# Altium schematic sheet 02_USB2.0_Module.SchDoc
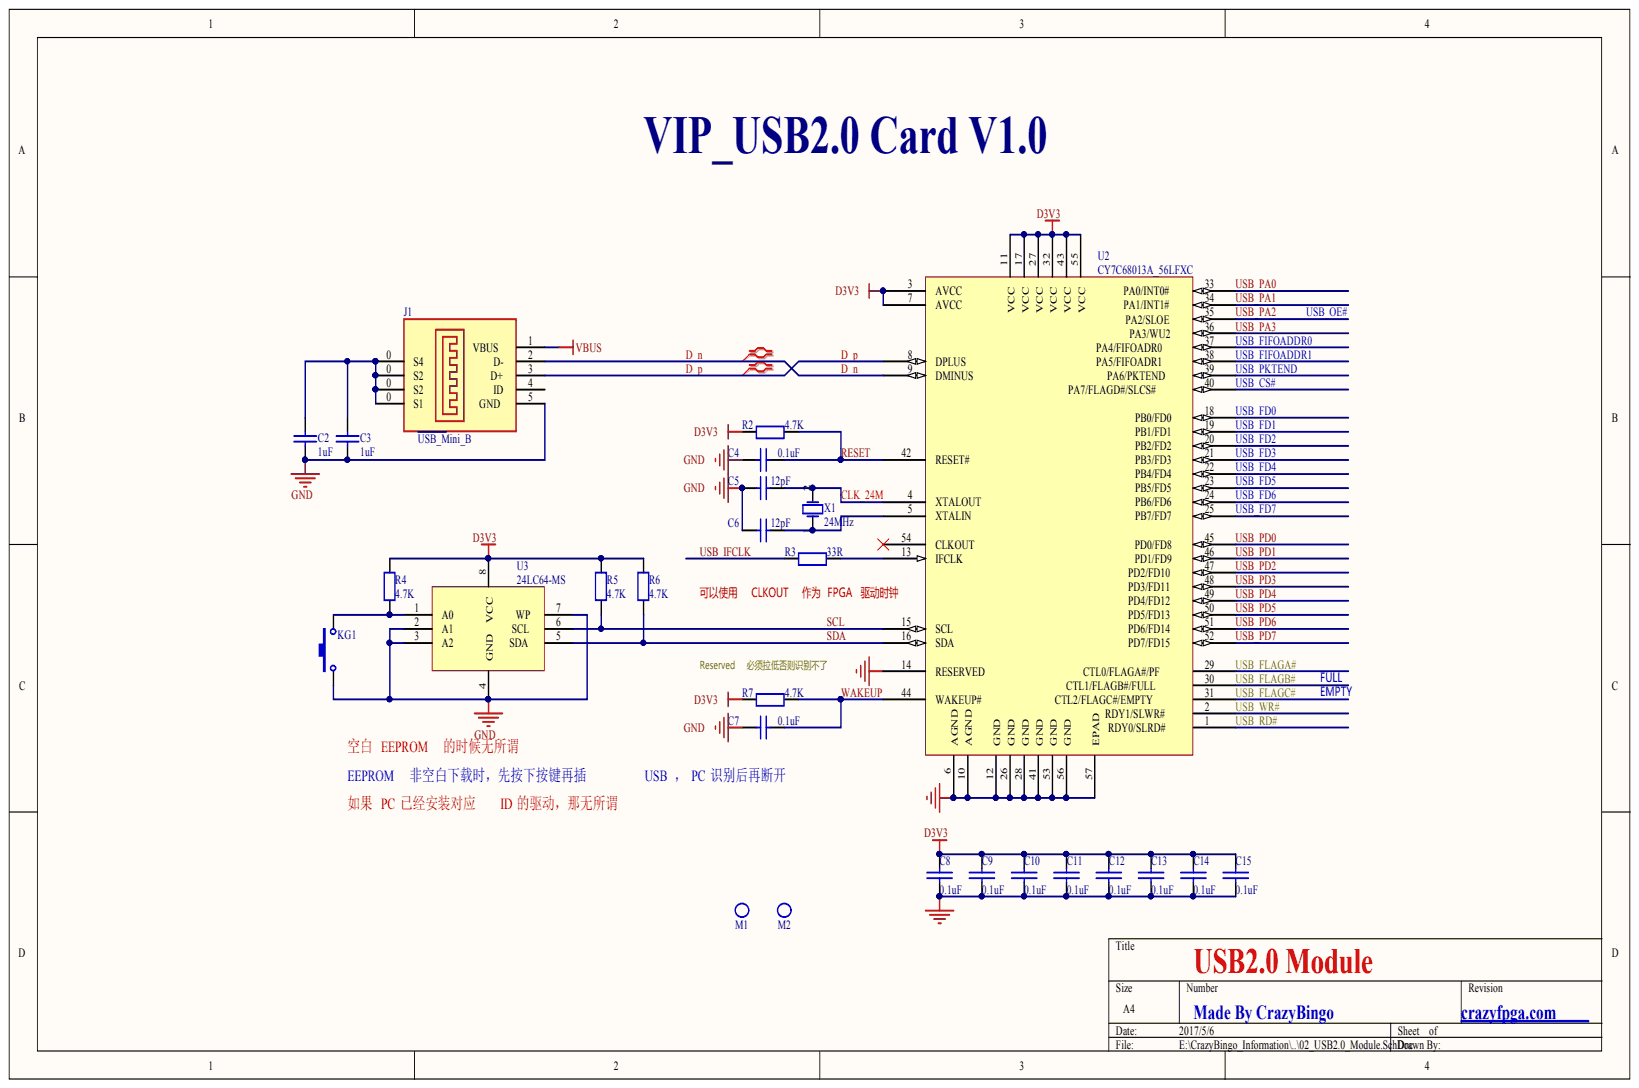
[diagram p0: 02_USB2.0_Module.SchDoc]

## Parts
C2  1uF  [R0603]
C3  1uF  [R0603]
C4  0.1uF  [R0603]
C5  12pF  [R0603]
C6  12pF  [R0603]
C7  0.1uF  [R0603]
C8  0.1uF  [R0603]
C9  0.1uF  [R0603]
C10  0.1uF  [R0603]
C11  0.1uF  [R0603]
C12  0.1uF  [R0603]
C13  0.1uF  [R0603]
C14  0.1uF  [R0603]
C15  0.1uF  [R0603]
J1  USB_Mini_B  [USB_Mini_B]
KG1  KEY  [KEY2]  (value not drawn)
M1  Pin_Mark  [Pin_Mark_One]  (value not drawn)
M2  Pin_Mark  [Pin_Mark_One]  (value not drawn)
R2  4.7K  [R0603]
R3  33R  [R0603]
R4  4.7K  [R0603]
R5  4.7K  [R0603]
R6  4.7K  [R0603]
R7  4.7K  [R0603]
U2  CY7C68013A_56LFXC  [QFN56]
U3  24LC64-MS  [MSOP8]
X1  24MHz  [CRYSTAL_5032]

## Nets
D3V3: C8.1 C9.1 C10.1 C11.1 C12.1 C13.1 C14.1 C15.1 R2.1 R4.2 R5.2 R6.2 R7.1 U2.3(AVCC) U2.7(AVCC) U2.11(VCC) U2.17(VCC) U2.27(VCC) U2.32(VCC) U2.43(VCC) U2.55(VCC) U3.8(VCC)
GND: C2.1 C3.1 C4.1 C5.2 C6.2 C7.1 C8.2 C9.2 C10.2 C11.2 C12.2 C13.2 C14.2 C15.2 J1.5(GND) KG1.1 U2.6(AGND) U2.10(AGND) U2.12(GND) U2.14(RESERVED) U2.26(GND) U2.28(GND) U2.41(GND) U2.53(GND) U2.56(GND) U2.57(EPAD) U3.2(A1) U3.3(A2) U3.4(GND) U3.7(WP)
VBUS: J1.1(VBUS)
CLK_24M: C5.1 U2.4(XTALOUT) X1.2
D_N: J1.2(D-) U2.9(DMINUS)
D_P: J1.3(D+) U2.8(DPLUS)
RESET: C4.2 R2.2 U2.42(RESET#)
SCL: R5.1 U2.15(SCL) U3.6(SCL)
SDA: R6.1 U2.16(SDA) U3.5(SDA)
USB_CS#: U2.40
USB_FD0: U2.18(PB0/FD0)
USB_FD1: U2.19(PB1/FD1)
USB_FD2: U2.20(PB2/FD2)
USB_FD3: U2.21(PB3/FD3)
USB_FD4: U2.22(PB4/FD4)
USB_FD5: U2.23(PB5/FD5)
USB_FD6: U2.24(PB6/FD6)
USB_FD7: U2.25(PB7/FD7)
USB_FIFOADDR0: U2.37
USB_FIFOADDR1: U2.38
USB_FLAGA#: U2.29
USB_FLAGB#: U2.30
USB_FLAGC#: U2.31
USB_IFCLK: R3.1
USB_OE#: U2.35(PA2/SLOE)
USB_PA0: U2.33(PA0/INT0#)
USB_PA1: U2.34(PA1/INT1#)
USB_PA3: U2.36(PA3/WU2)
USB_PD0: U2.45(PD0/FD8)
USB_PD1: U2.46(PD1/FD9)
USB_PD2: U2.47(PD2/FD10)
USB_PD3: U2.48(PD3/FD11)
USB_PD4: U2.49(PD4/FD12)
USB_PD5: U2.50(PD5/FD13)
USB_PD6: U2.51(PD6/FD14)
USB_PD7: U2.52(PD7/FD15)
USB_PKTEND: U2.39
USB_RD#: U2.1
USB_WR#: U2.2
WAKEUP: C7.2 R7.2 U2.44(WAKEUP#)
NetC2_2: C2.2 C3.2 J1.0(S4)
NetC6_1: C6.1 U2.5(XTALIN) X1.1
NetKG1_2: KG1.2 R4.1 U3.1(A0)
NetR3_2: R3.2 U2.13(IFCLK)
N00242:

Not connected: J1 4(ID); U2 54(CLKOUT)

## Library data of the parts
C2 C3 = Cap: Capacitor; Value: 0.1uF; Component Kind: Standard; LatestRevisionDate: 17-Jul-2002; LatestRevisionNote: Re-released for DXP Platform.; PackageReference: RAD-0.3; Published: 8-Jun-2000; Library Name: EnHom.SchLib; Publisher: Altium Limited
C4 C7 = Cap: Capacitor; Component Kind: Standard; LatestRevisionDate: 17-Jul-2002; LatestRevisionNote: Re-released for DXP Platform.; PackageReference: RAD-0.3; Published: 8-Jun-2000; Value: 0.1uF; Library Name: EnHom.SchLib; Publisher: Altium Limited
C5 C6 = CAP: Component Kind: Standard; Library Name: Crazy_PCB.SchLib; Value: 12pF
C8-C15 = CAP: Capacitor; Component Kind: Standard; Library Name: RC522.IntLib
J1 = USB_Mini: Maker: Molex; Model: 54819-0578; OrderNr: 418-0172; Component Kind: Standard; Distributor: RS; Library Name: Crazy_PCB.SchLib; Price: 4,29 (5)
KG1 = KEY2: Switch; Component Kind: Standard; LatestRevisionDate: 17-Jul-2002; LatestRevisionNote: Re-released for DXP Platform.; Library Name: Crazy_PCB.SchLib; PackageReference: SPST-2; Published: 24-Mar-1999; Publisher: Altium Limited
R2 R3 R7 = RES: Component Kind: Standard; Library Name: Crazy_PCB.SchLib
R4-R6 = RES: Resistor; Value: 1K; Component Kind: Standard; Library Name: Crazy_PCB.SchLib; Published: 8-Jun-2000; Revision: July-2002: Re-released for DXP Platform.; Publisher: Altium Limited
U3 = 24LC64-SM: Component Kind: Standard; Library Name: Crazy_PCB.SchLib
X1 = CRYSTAL: Crystal Oscillator; Component Kind: Standard; LatestRevisionDate: 17-Jul-2002; LatestRevisionNote: Re-released for DXP Platform.; Library Name: Crazy_PCB.SchLib; PackageReference: R38; Published: 8-Jun-2000; Vaule: 24MHz; Publisher: Altium Limited
M1 M2 = Pin_Mark: Component Kind: Standard; Library Name: Crazy_PCB.SchLib
U2 = CY7C68013A_56LFXC: Component Kind: Standard; Library Name: Crazy_PCB.SchLib
